# Altium schematic sheet 11 USB Host with Power Limt Switches.SchDoc
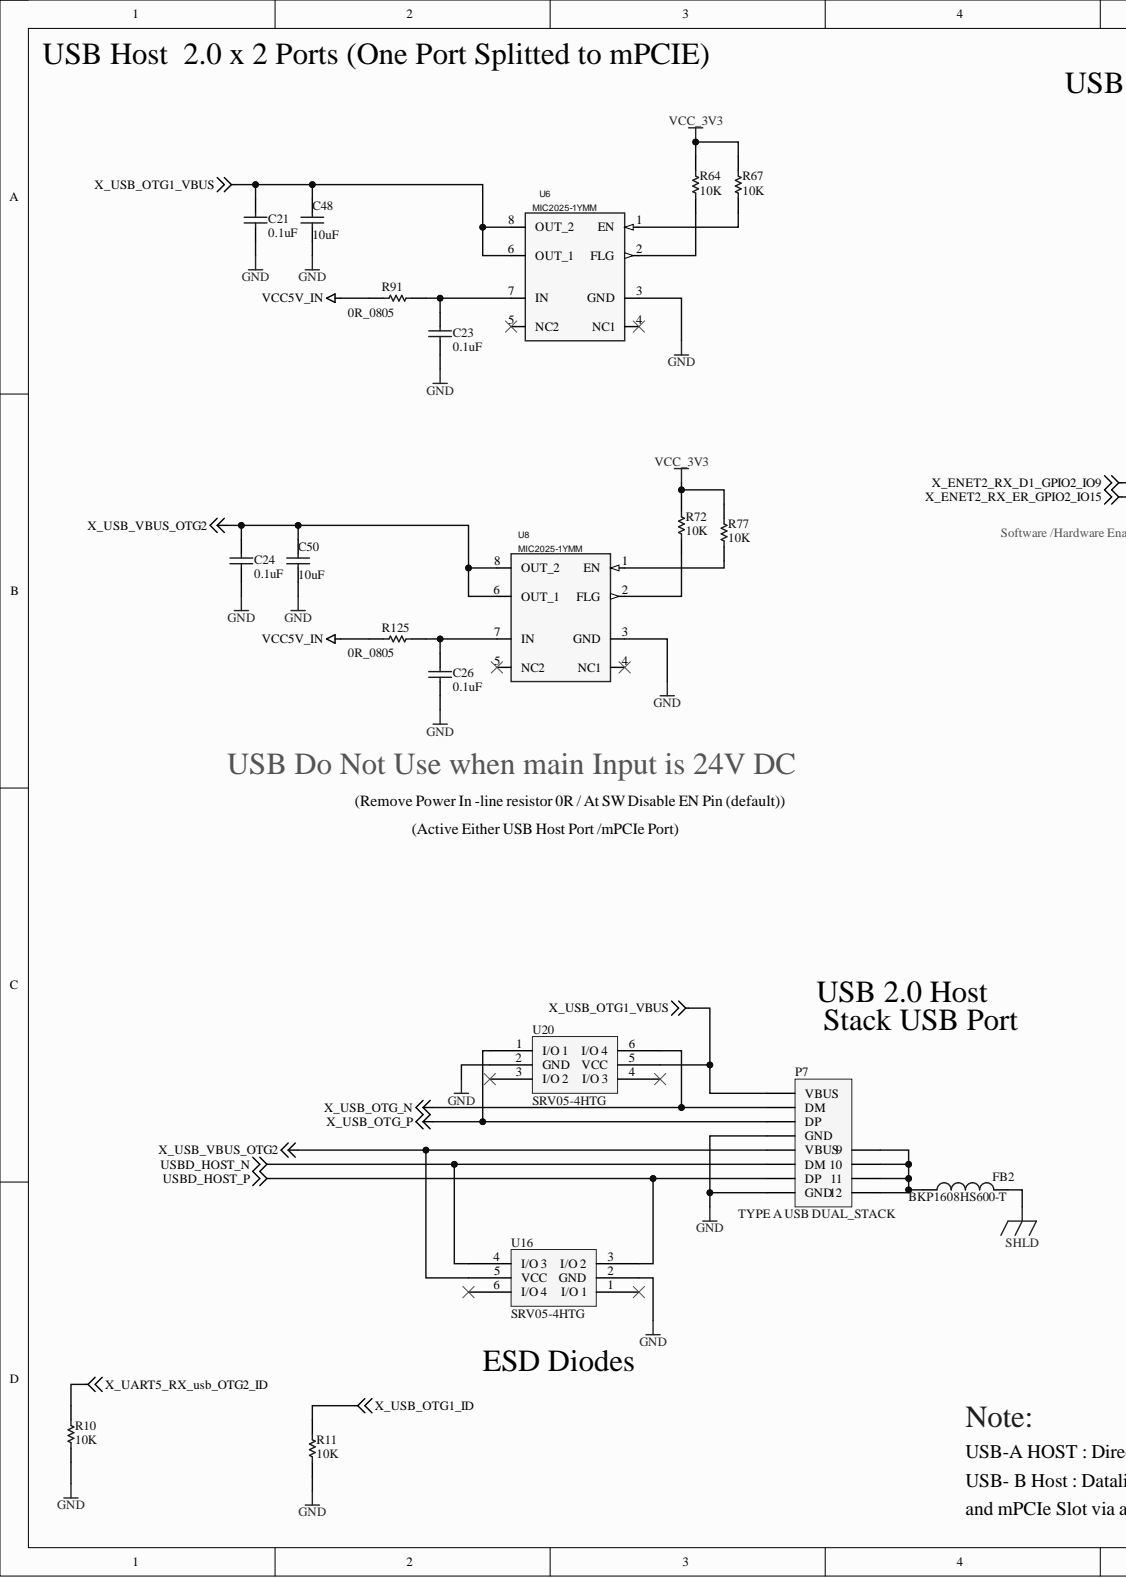
[diagram p0: 11 USB Host with Power Limt Switches.SchDoc, left]
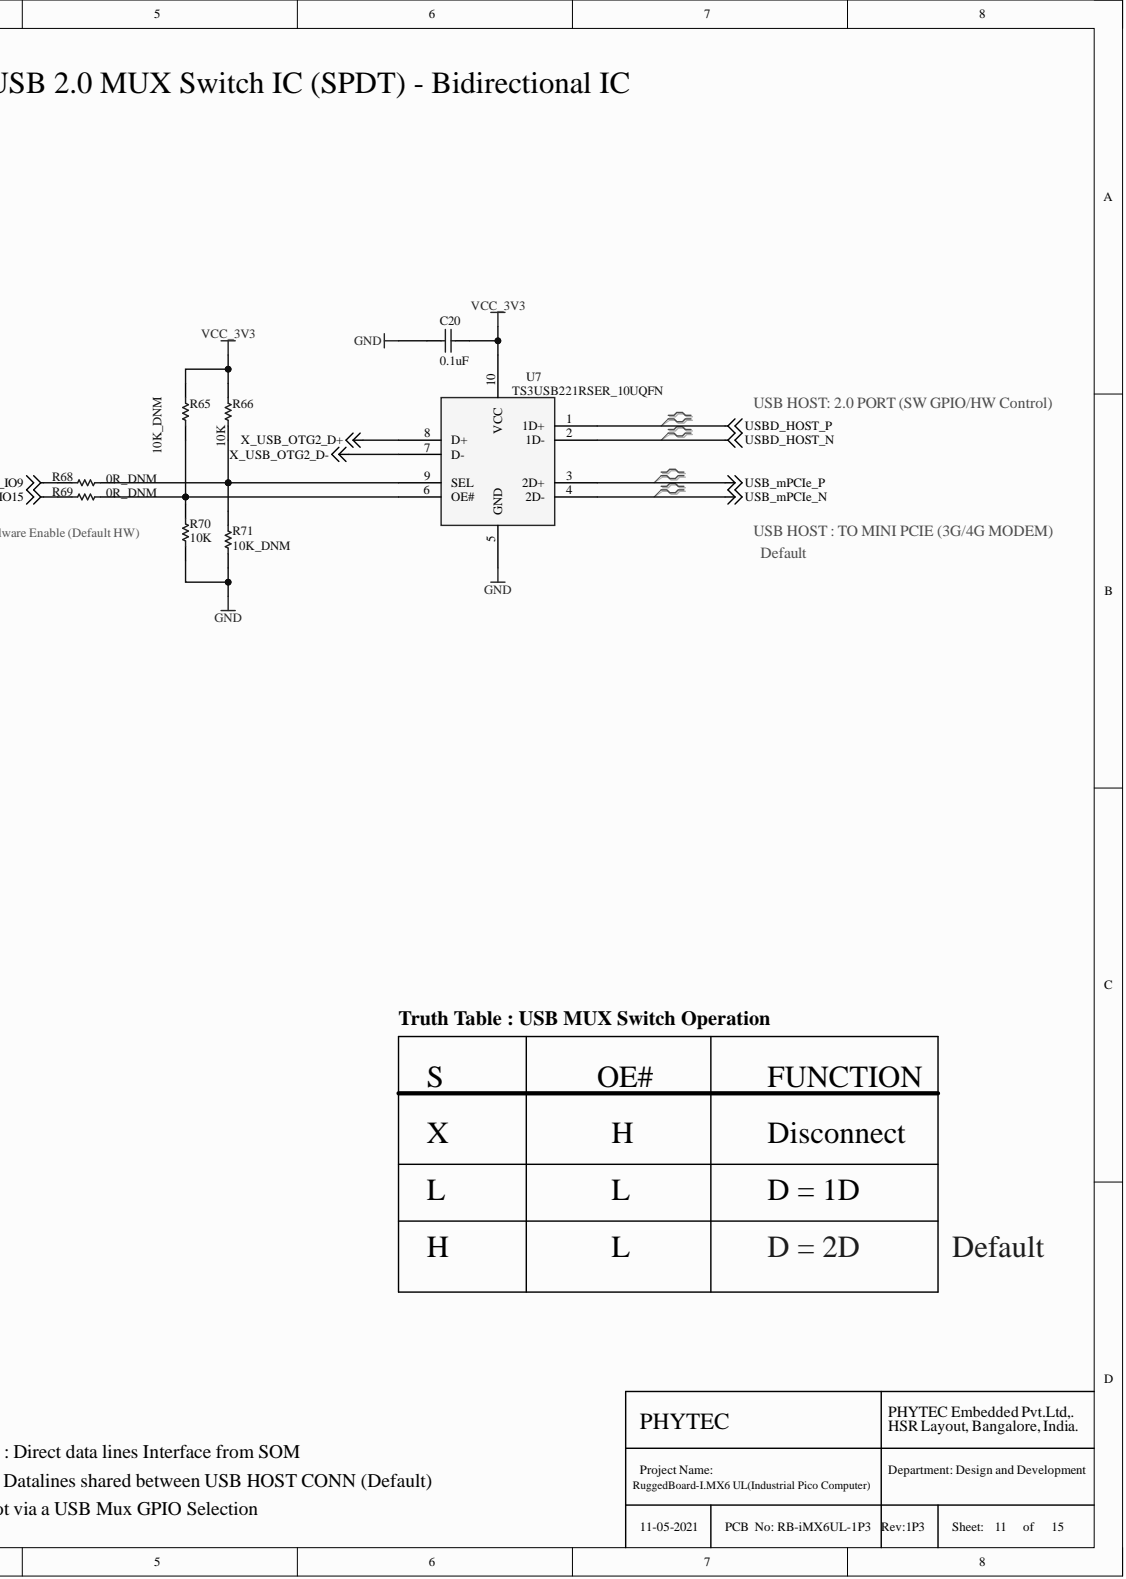
[diagram p1: 11 USB Host with Power Limt Switches.SchDoc, right]

## Parts
C20  0.1uF  [C0402]
C21  0.1uF  [C0402]
C23  0.1uF  [C0402]
C24  0.1uF  [C0402]
C26  0.1uF  [C0402]
C48  10uF  [C0603]
C50  10uF  [C0603]
FB2  BKP1608HS600-T  [L0603]
P7  TYPE A USB DUAL_STACK  [USB - A-61400826021]
R10  10K  [R0402]
R11  10K  [R0402]
R64  10K  [R0402]
R65  10K_DNM  [R0402]
R66  10K  [R0402]
R67  10K  [R0402]
R68  0R_DNM  [R0402]
R69  0R_DNM  [R0402]
R70  10K  [R0402]
R71  10K_DNM  [R0402]
R72  10K  [R0402]
R77  10K  [R0402]
R91  0R_0805  [R0805]
R125  0R_0805  [R0805]
U6  MIC2025-1YMM  [MSOP8_122X122_25Pnopad]
U7  TS3USB221RSER_10UQFN  [UQFN10_2X15MM_05P]
U8  MIC2025-1YMM  [MSOP8_122X122_25Pnopad]
U16  SRV05-4HTG  [SOT95P280X145-6N]
U20  SRV05-4HTG  [SOT95P280X145-6N]

## Nets
GND: C20.2 C21.1 C23.1 C24.1 C26.1 C48.1 C50.1 P7.4(GND) P7.8(GND) R10.2 R11.2 R70.2 R71.2 U6.3(GND) U7.5(GND) U8.3(GND) U16.2(GND) U20.2(GND)
SHLD: FB2.2
USB_mPCIe_N: U7.4(2D-)
USB_mPCIe_P: U7.3(2D+)
USBD_HOST_N: P7.6(DM) U7.2(1D-) U16.4(I/O_3)
USBD_HOST_P: P7.7(DP) U7.1(1D+) U16.3(I/O_2)
VCC5V_IN: R91.2 R125.2
VCC_3V3: C20.1 R64.1 R65.1 R66.1 R67.1 R72.1 R77.1 U7.10(VCC)
X_ENET2_RX_D1_GPIO2_IO9: R68.2
X_ENET2_RX_ER_GPIO2_IO15: R69.2
X_UART5_RX_usb_OTG2_ID: R10.1
X_USB_OTG1_ID: R11.1
X_USB_OTG1_VBUS: C21.2 C48.2 P7.1(VBUS) U6.6(OUT_1) U6.8(OUT_2) U20.5(VCC)
X_USB_OTG2_D+: U7.8(D+)
X_USB_OTG2_D-: U7.7(D-)
X_USB_OTG_N: P7.2(DM) U20.6(I/O_4)
X_USB_OTG_P: P7.3(DP) U20.1(I/O_1)
X_USB_VBUS_OTG2: C24.2 C50.2 P7.5(VBUS) U8.6(OUT_1) U8.8(OUT_2) U16.5(VCC)
NetC23_2: C23.2 R91.1 U6.7(IN)
NetC26_2: C26.2 R125.1 U8.7(IN)
NetFB2_1: FB2.1 P7.9 P7.10 P7.11 P7.12
NetR64_2: R64.2 U6.2(FLG)
NetR65_2: R65.2 R69.1 R70.1 U7.6(OE#)
NetR66_2: R66.2 R68.1 R71.1 U7.9(SEL)
NetR67_2: R67.2 U6.1(EN)
NetR72_2: R72.2 U8.2(FLG)
NetR77_2: R77.2 U8.1(EN)

Not connected: U6 4(NC1) 5(NC2); U8 4(NC1) 5(NC2); U16 1(I/O_1) 6(I/O_4); U20 3(I/O_2) 4(I/O_3)

## Library data of the parts
C20 C21 C23 C24 C26 = Cap: CAP CER 0.1UF 50V X7R 0402; ASSEMBLY_TYPE: SMT; MFG: Murata; MFG_PN: GRM155R71H104ME14D; Component Kind: Standard; Library Name: Miscellaneous Devices.IntLib; PACKAGE: 0402; Signal Integrity: Cap; Simulation: CAP
C48 C50 = Cap: CAP CER 10UF 16V X5R 0603; ASSEMBLY_TYPE: SMT; MACRO: Mini PCIe CONN; MFG: Murata; MFG_PN: GRM188R61C106KAALD; Component Kind: Standard; Library Name: Miscellaneous Devices.IntLib; PACKAGE: 0603
FB2 = Inductor: FERRITE BEAD 60 OHM 0603 1LN; ASSEMBLY_TYPE: SMT; MACRO: Power For Ethernet PHY Transceiver; MFG: Taiyo Yuden; Component Kind: Standard; Library Name: Miscellaneous Devices.IntLib; MFG_PN: BKP1608HS600-T; PACKAGE: 0603; Signal Integrity: Inductor; Simulation: INDUCTOR; TEMPERATURE: -55°C ~ 85°C
P7 = Header 12: CONN COM TYPE A USB DUAL 2X4POS; ASSEMBLY_TYPE: TH; MFG: WE; MFG_PN: 61400826021; Component Kind: Standard; Library Name: TVS i,MX6 Mother Board1.SCHLIB; PACKAGE: TH
R10 R11 R64-R67 R70-R72 R77 = Res1: RES SMD 10K OHM 1% 1/16W 0402; ASSEMBLY_TYPE: SMT; MFG: YAGEO; MFG_PN: RC0402FR-0710KL; Component Kind: Standard; Library Name: Miscellaneous Devices.IntLib; PACKAGE: 0402; Signal Integrity: Res; Simulation: RESISTOR
R68 R69 = Res1: RES SMD 0.0OHM JUMPER 1/16W 0402; ASSEMBLY_TYPE: SMT; MFG: YAGE0; MFG_PN: RC0402JR-070RL; Component Kind: Standard; Library Name: Miscellaneous Devices.IntLib; PACKAGE: 0402; Signal Integrity: Res; Simulation: RESISTOR
R91 R125 = Res1: RES SMD 0.0OHM JUMPER 1/8W 0805; ASSEMBLY_TYPE: SMT; MACRO: Power LDO 5V0 and 3V3 (L7986); MFG: Yageo; MFG_PN: AC0805JR-070RL; Component Kind: Standard; Library Name: Miscellaneous Devices.IntLib; PACKAGE: 0805; TEMPERATURE: -55°C ~ 155°C
U6 U8 = MIC2025: IC DISTRIBUTION SW SGL 8-MSO_ Active High; MFG: Microchip Technology; Component Kind: Standard; MFG_PN: MIC2025-1YMM; PACKAGE: 8-MSOP
U7 = TS3USB221RSER: IC USB SWITCH DUAL 1X2 10UQFN; MFG: TI; MFG_PN: TS3USB221RSER_10-UQFN; Component Kind: Standard; Library Name: Schlib1.SchLib; PACKAGE: 10-UQFN
U16 U20 = SRV05-4HTG: TVS DIODE 6V 15V SOT23-6; ASSEMBLY_TYPE: SMD; MFG: Littelfuse; Component Kind: Standard; MFG_PN: SRV05-4HTG; PACKAGE: SOT-23-6; Library Name: PHY_LIBRARY.SchLib
